# Altium schematic sheet LDC SCreen.SchDoc
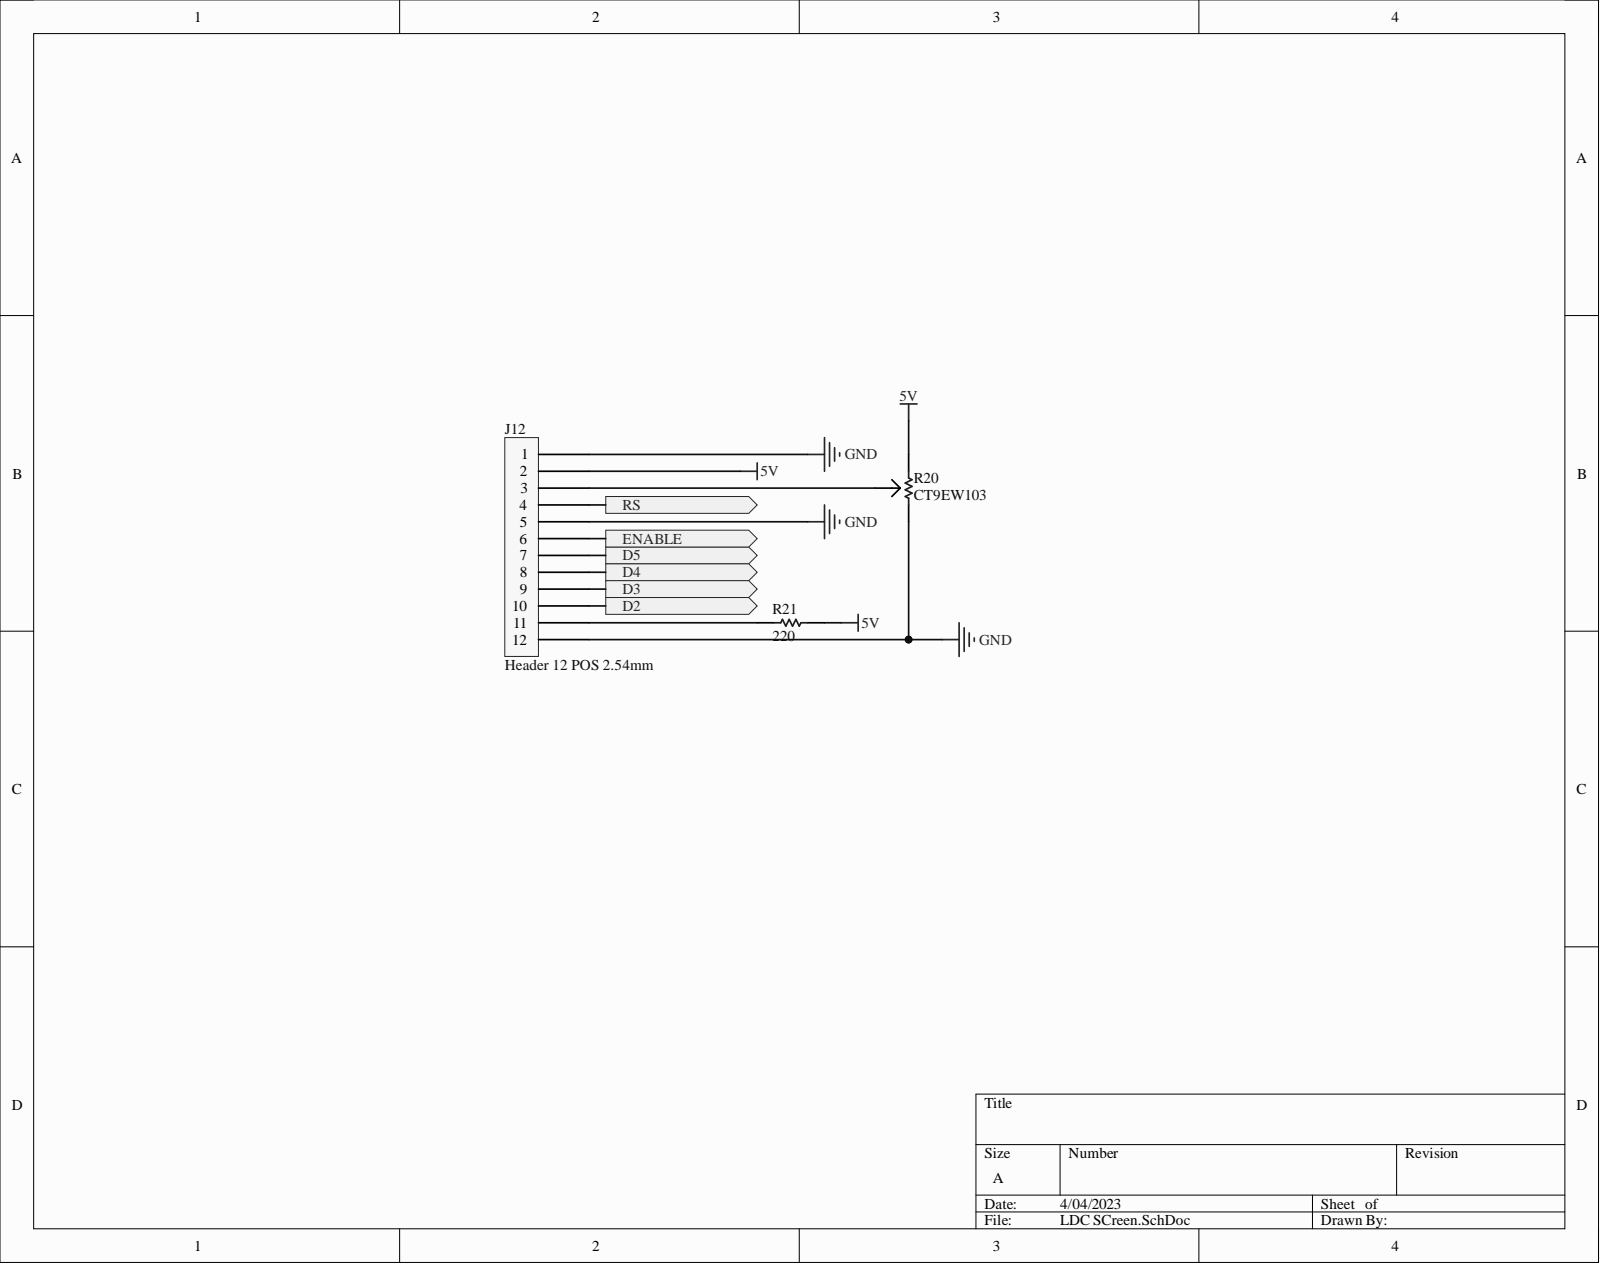
[diagram p0: LDC SCreen.SchDoc]

## Parts
J12  Header 12 POS 2.54mm  [Header 12 POS 2.54mm-Footprint-1]
R20  CT9EW103  [CT9EW103-Footprint-1]
R21  Panasonic ERJ-6GEYJ911V  [RESC2013X70X40ML20T20]  (value not drawn)

## Ports
D2, D3, D4, D5, ENABLE, RS

## Nets
5V: J12.2 R20.3 R21.2
GND: J12.1 J12.5 J12.12 R20.1
D2: J12.10 ; port D2
D3: J12.9 ; port D3
D4: J12.8 ; port D4
D5: J12.7 ; port D5
ENABLE: J12.6 ; port ENABLE
RS: J12.4 ; port RS
NetJ12_3: J12.3 R20.2
NetJ12_11: J12.11 R21.1

## Library data of the parts
J12 = CMP-6l48lxls9gskq8icf2jw-1: Header 12 Position 1x12 2.54mm pitch; Component Kind: Standard; CustomComponentID: 6l48lxls9gskq8icf2jw; Library Name: My Personal Space
R20 = CMP-1xo8upo7lbbrmnhgjmzs-1: TRIMMER 10K OHM 0.5W PC PIN TOP; Component Kind: Standard; CustomComponentID: 1xo8upo7lbbrmnhgjmzs; Digkey Url: https://www.digikey.com/en/products/detail/nidec-copal-electronics/CT9EW103/738448; Library Name: My Personal Space
R21 = CMP-3670835-2: Thick Film Resistors - SMD 0805 910ohms 5% Tol; Case/Package (SI): 2012; Lead-Free Status: Lead Free; Power Rating: 0.125 W; Resistance: 220; Voltage Rating (DC): 150.0 V; Case/Package: 0805; Component Kind: Standard; Library Name: My Personal Space; Lifecycle Status: Not Listed by Manufacturer; Manufacturer Part Number: ERJ-6GEYJ911V; Mounting Style: Surface Mount; Octopart Url: https://octopart.com/erj-6geyj911v-panasonic-55423073; OctopartID: 40418a06d5469f86; Packaging: Cut Tape (CT); REACH SVHC Compliance: No SVHC; Resistance Tolerance: ±5%; RoHS: Compliant; Size-Height: 0.0006 m; Size-Length: 0.002 m; Size-Width: 0.00125 m
